# Altium schematic sheet 4bandequi.SchDoc(4bandequi)
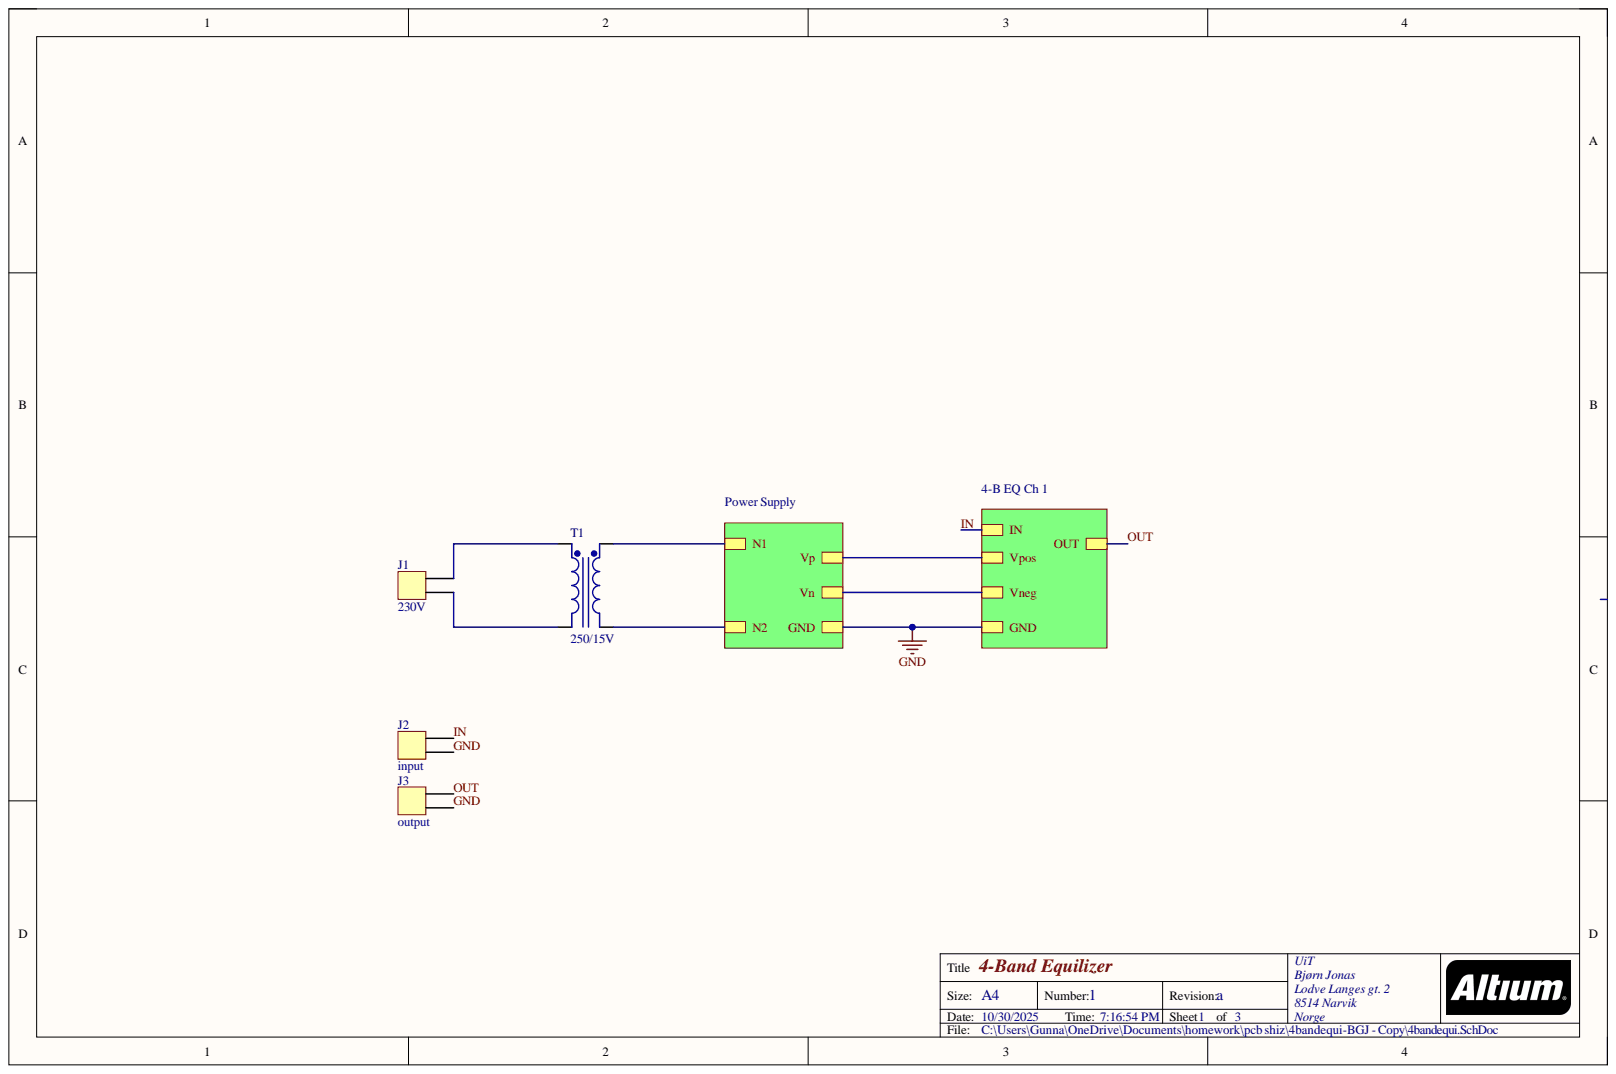
[diagram p0: 4bandequi.SchDoc]

## Parts
J1  230V  [Header 2]
J2  input  [150mil header]
J3  output  [150mil header]
T1  250/15V  [trans]

## Nets
GND: J2.2 J3.2
IN: J2.1
OUT: J3.1
NetJ1_1: J1.1 T1.1
NetJ1_2: J1.2 T1.2
NetT1_3: T1.3
NetT1_4: T1.4
Vn:
Vp:

## Library data of the parts
J1-J3 = Header_2: Component Kind: Standard; Signal Integrity: Model Name; Library Name: 4bandequi.SchLib
T1 = Trans Eq: Transformer (Equivalent Circuit Model); Component Kind: Standard; LatestRevisionDate: 17-Jul-2002; LatestRevisionNote: Re-released for DXP Platform.; Library Name: Miscellaneous Devices.IntLib; PackageDescription: Transformer; 4 Leads; PackageReference: TRF_4; Published: 8-Jun-2000; Simulation: TRANS; Publisher: Altium Limited
